# Altium schematic sheet mpc57_can.SchDoc(mpc57_can)
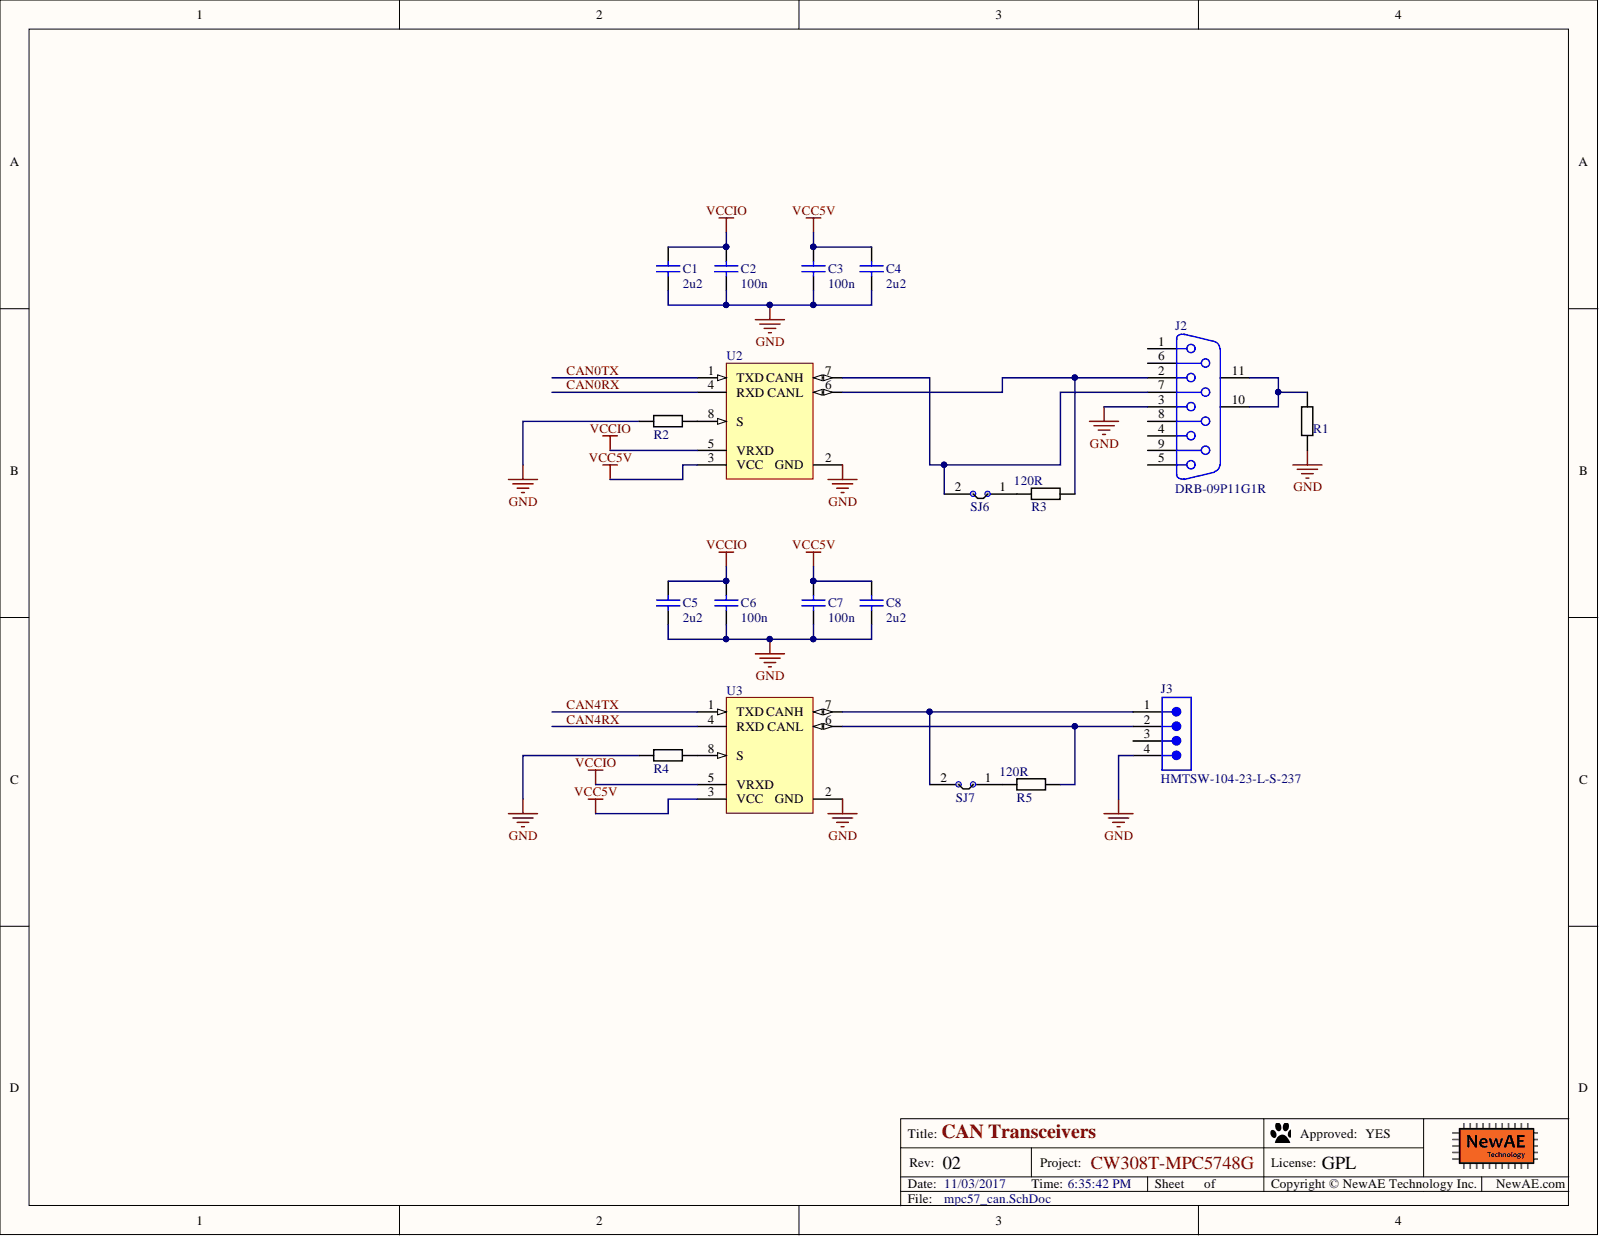
[diagram p0: mpc57_can.SchDoc]

## Parts
C1  2u2  [0603]
C2  100n  [0402]
C3  100n  [0402]
C4  2u2  [0603]
C5  2u2  [0603]
C6  100n  [0402]
C7  100n  [0402]
C8  2u2  [0603]
J2  DRB-09P11G1R  [TAIT-DRB-09P11G1R_V]
J3  HMTSW-104-23-L-S-237  [SMTC-HMTSW-104-23-X-S-237]
R1  10R  [0603]  (value not drawn)
R2  4k7  [0603]  (value not drawn)
R3  120R  [0603]
R4  4k7  [0603]  (value not drawn)
R5  120R  [0603]
SJ6  NC  [SJ_2S_NC]  (value not drawn)  (no BOM)
SJ7  NC  [SJ_2S_NC]  (value not drawn)  (no BOM)
U2  TJA1051T/3  [SOIC8-NARROW]  (value not drawn)
U3  TJA1051T/3  [SOIC8-NARROW]  (value not drawn)

## Nets
GND: C1.2 C2.2 C3.2 C4.2 C5.2 C6.2 C7.2 C8.2 J2.3 J3.4 R1.1 R2.1 R4.1 U2.2(GND) U3.2(GND)
VCC5V: C3.1 C4.1 C7.1 C8.1 U2.3(VCC) U3.3(VCC)
VCCIO: C1.1 C2.1 C5.1 C6.1 U2.5(VRXD) U3.5(VRXD)
CAN0RX: U2.4(RXD)
CAN0TX: U2.1(TXD)
CAN4RX: U3.4(RXD)
CAN4TX: U3.1(TXD)
NetJ2_2: J2.2 R3.2 U2.6(CANL)
NetJ2_7: J2.7 SJ6.2 U2.7(CANH)
NetJ2_10: J2.10 J2.11 R1.2
NetJ3_1: J3.1 SJ7.2 U3.7(CANH)
NetJ3_2: J3.2 R5.2 U3.6(CANL)
NetR2_2: R2.2 U2.8(S)
NetR3_1: R3.1 SJ6.1
NetR4_2: R4.2 U3.8(S)
NetR5_1: R5.1 SJ7.1

Not connected: J2 1 4 5 6 8 9; J3 3

## Library data of the parts
C1 C4 C5 C8 = CCHIP-2u2-0603: 2u2, 0603; Database Table Name: main; Case-Metric: 1608; Value: 2u2; Pin Count: 2; Part Number: CCHIP-2u2-0603; Mounting Technology: SMT; Library Name: NewAE_AltiumLib.DBLib; Component Type: Standard; Component Kind: Standard; Case-EIA: 0603
C2 C3 C6 C7 = CCHIP-100n-0402: 100n, 0402; Database Table Name: main; Value: 100n; Pin Count: 2; Part Number: CCHIP-100n-0402; Mounting Technology: SMT; Library Name: NewAE_AltiumLib.DBLib; Component Type: Standard; Component Kind: Standard; Case-EIA: 0402
J2 = CMP-0264-00001-1: DB9 R/A Connector, Through-hole, Male; PartNumber: DRB-09P11G1R; PackageReference: DRB-09P11G1R; PackageDescription: 9-Pin D-Sub R/A  Male Connector, 2.77 mm Pitch; Mounting Technology: Through Hole; Library Name: Altium Content Vault; ComponentLink2URL: http://www.taitek.com/connects_prod_info.asp?id=A100011; ComponentLink2Description: Datasheet; ComponentLink1URL: http://www.taitek.com/; ComponentLink1Description: Manufacturer URL; Component Kind: Standard
J3 = CMP-1024-00020-1: Manufacturer: Samtec; Pitch: 2.54 mm; Temperature Range: -55 to 125 degC; RoHS: True; PartNumber: HMTSW-104-23-L-S-237; PackageVersion: AL, 3/1987; PackageReference: HMTSW-104-23-X-S-237; PackageDescription: Assembly .025 [.64] SQ. Post Hi-Temp Terminal Strip; Orientation: Vertical; Mounting Technology: Through-hole; Library Name: Altium Content Vault; DatasheetVersion: F-214; ComponentLink3URL: http://www.samtec.com/documents/webfiles/cpdf/HMTSW-XXX-XX-X-X-XXXX-XX-XX-MKT1.pdf; ComponentLink3Description: Package Specification; ComponentLink2URL: http://www.samtec.com/documents/webfiles/pdf/HMTSW.PDF; ComponentLink2Description: Datasheet; ComponentLink1URL: http://www.samtec.com; ComponentLink1Description: Manufacturer URL; Component Kind: Standard
R1 = RCHIP-10R-0603: 10R res, 0603, 1%, 1/10W; Manufacture Part Number 1: RC0603FR-0710RL; Manufacture 1: Yageo; Rated Voltage: 75VDC; Rated Power: 0.1W; Database Table Name: main; Case-Metric: 1608; Value: 10R; Tolerance: 1%; Temperature Range: -55C to 155C; Supplier Part Number 1: 311-10.0HRCT-ND; Supplier 1: Digi-Key; Pin Count: 2; Part Number: RCHIP-10R-0603; Mounting Technology: SMT; Library Name: NewAE_AltiumLib.DBLib; Component Type: Standard; Component Kind: Standard; Case-EIA: 0603
R2 R4 = RCHIP-4K7-0603: 4k7 res, 0603, 1%, 1/10W; Manufacture Part Number 1: RC0603FR-074K7L; Manufacture 1: Yageo; Rated Voltage: 75VDC; Rated Power: 0.1W; Database Table Name: main; Case-Metric: 1608; Value: 4k7; Tolerance: 1%; Temperature Range: -55C to 155C; Supplier Part Number 1: 311-4.70KHRCT-ND; Supplier 1: Digi-Key; Pin Count: 2; Part Number: RCHIP-4K7-0603; Mounting Technology: SMT; Library Name: NewAE_AltiumLib.DBLib; Component Type: Standard; Component Kind: Standard; Case-EIA: 0603
R3 R5 = RCHIP-120R-0603: 120R res, 0603, 1%, 1/10W; Manufacture Part Number 1: RC0603FR-07120RL; Manufacture 1: Yageo; Rated Voltage: 75VDC; Rated Power: 0.1W; Database Table Name: main; Case-Metric: 1608; Value: 120R; Tolerance: 1%; Temperature Range: -55C to 155C; Supplier Part Number 1: 311-120HRCT-ND; Supplier 1: Digi-Key; Pin Count: 2; Part Number: RCHIP-120R-0603; Mounting Technology: SMT; Library Name: NewAE_AltiumLib.DBLib; Component Type: Standard; Component Kind: Standard; Case-EIA: 0603
SJ6 SJ7 = SJ_2S: Solder Jumper, NC; Database Table Name: main; Pin Count: 2; Part Number: SJ_2S; Library Name: NewAE_AltiumLib.DBLib; Component Type: Standard (No BOM)
U2 U3 = TJA1051T_3: CAN-FD Transceiver, 3.3V; Database Table Name: main; Supplier Part Number 1: 568-8684-1-ND; Supplier 1: Digi-Key; Pin Count: 8; Part Number: TJA1051T_3; Mounting Technology: Surface Mount; Library Name: NewAE_AltiumLib.DBLib; Component Type: Standard; ComponentLink1URL: http://www.nxp.com/documents/data_sheet/TJA1051.pdf; ComponentLink1Description: Datasheet; Component Kind: Standard
